# Altium schematic sheet iTimeAfrica.SchDoc(iTimeAfrica)
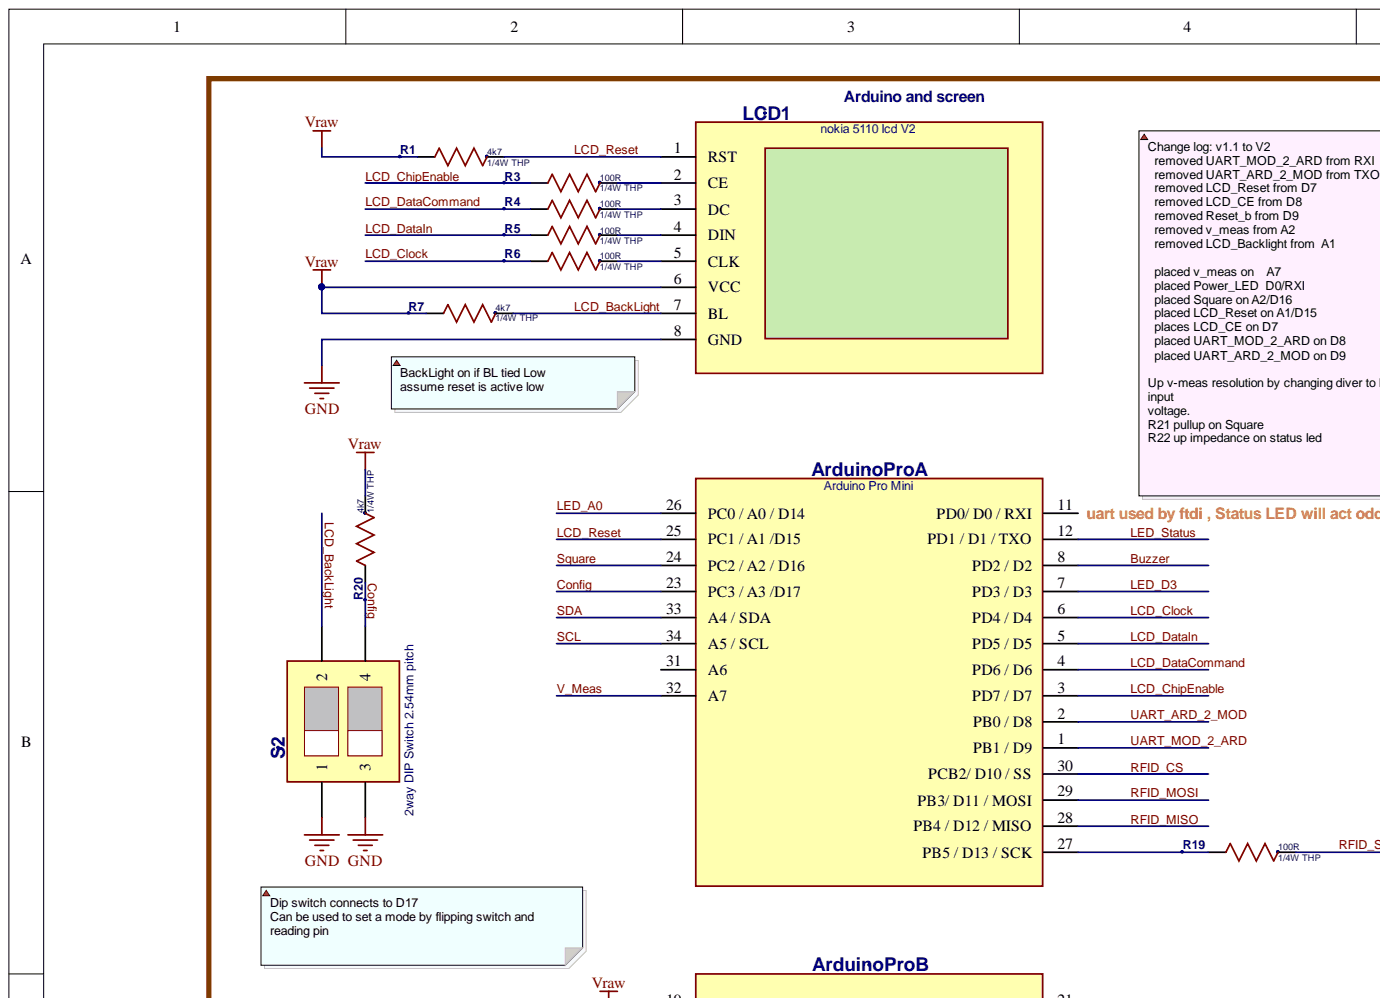
[diagram p0: iTimeAfrica.SchDoc, top left]
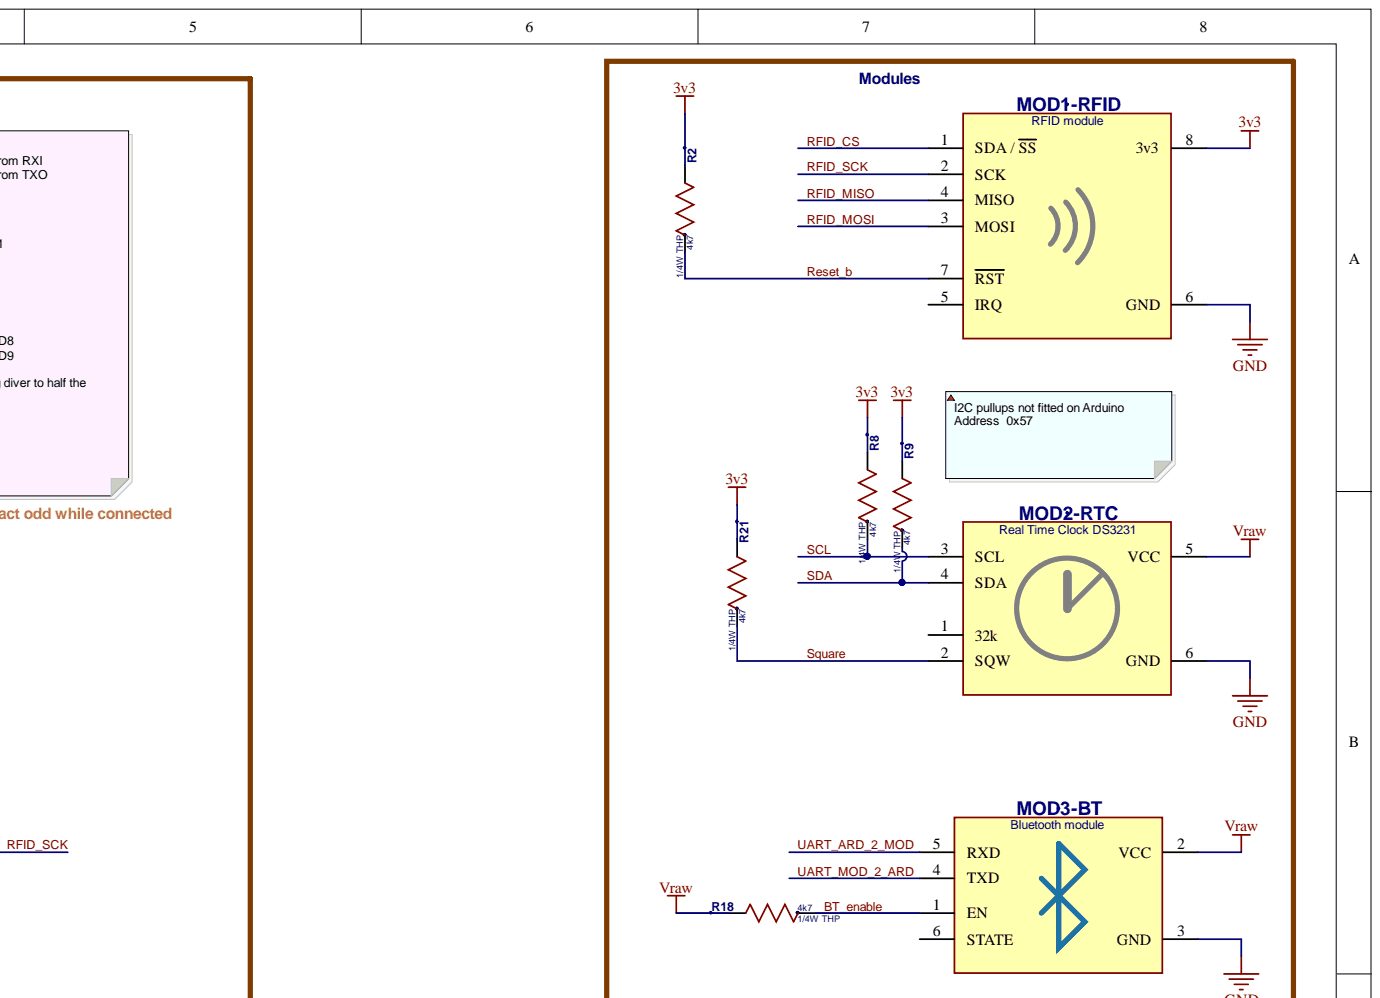
[diagram p1: iTimeAfrica.SchDoc, top right]
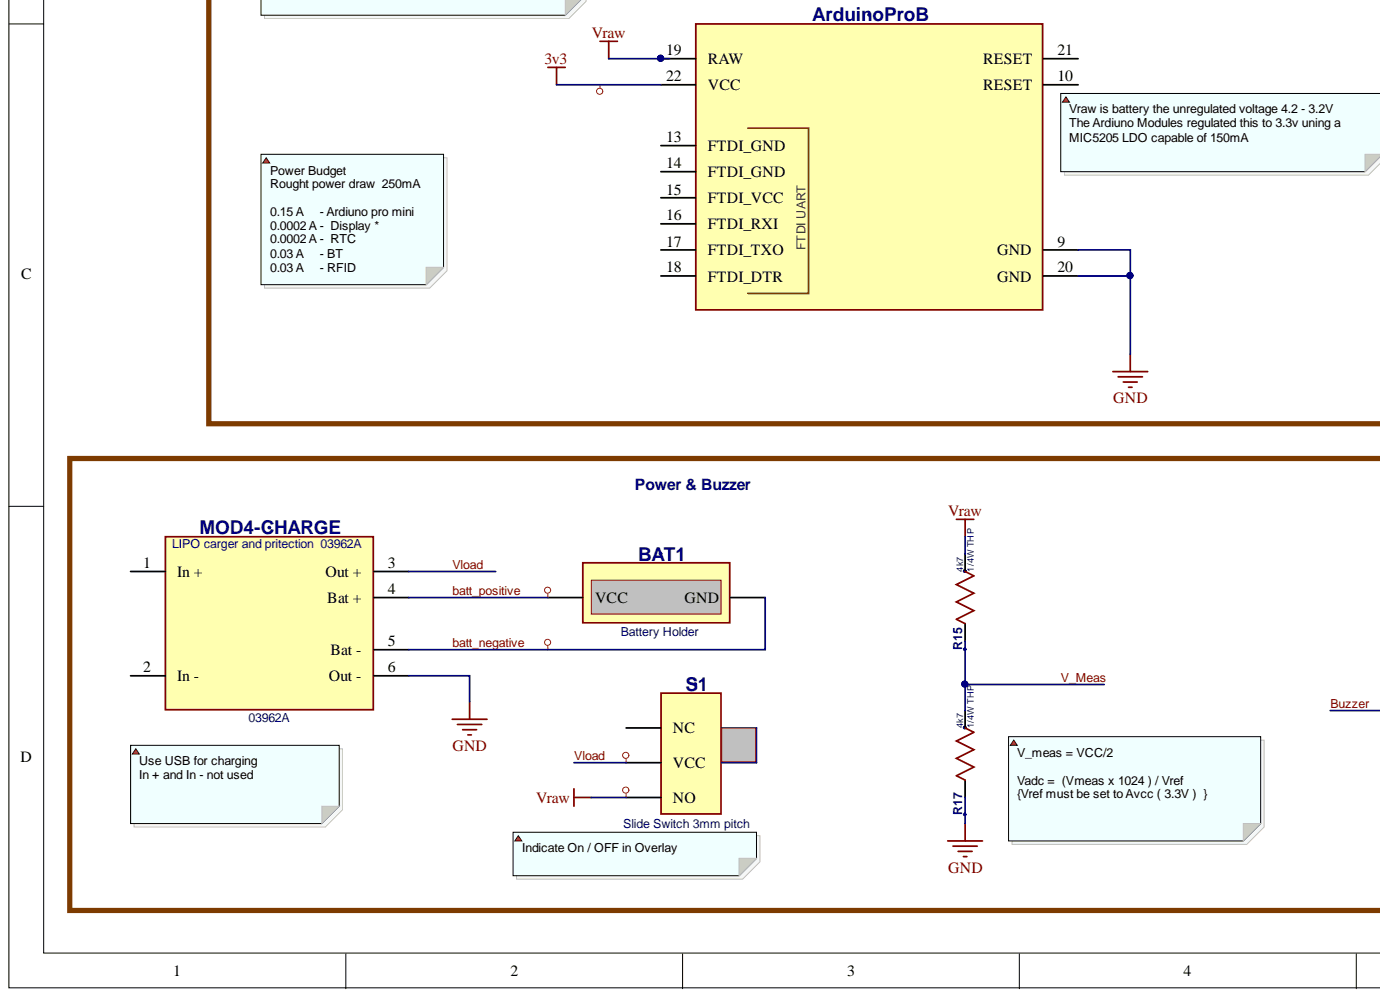
[diagram p2: iTimeAfrica.SchDoc, bottom left]
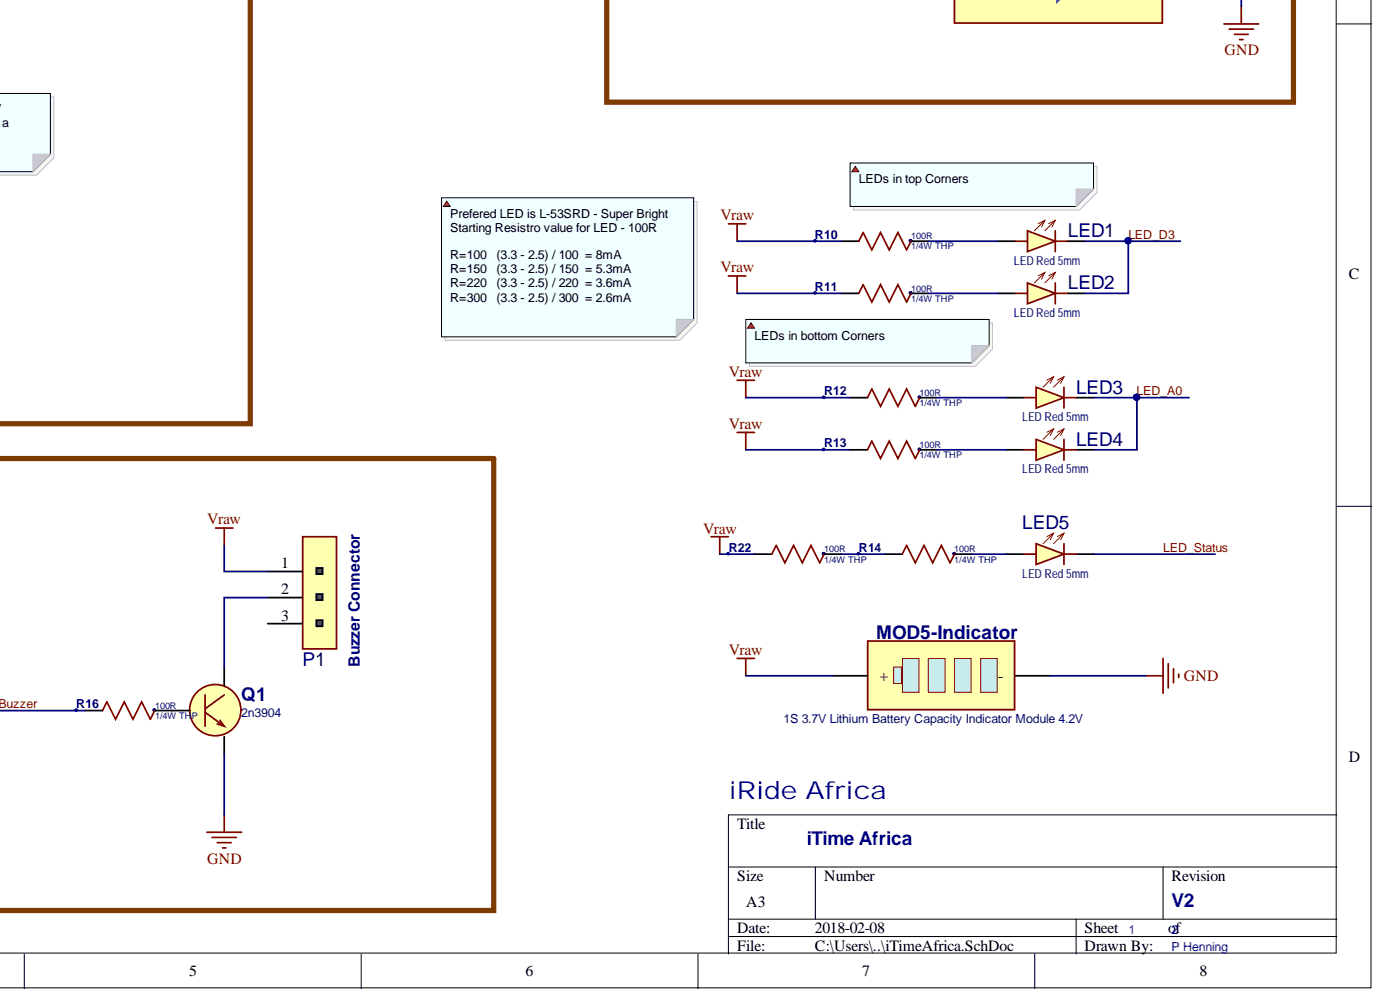
[diagram p3: iTimeAfrica.SchDoc, bottom right]

## Parts
ArduinoPro  Arduino Pro Mini  [ARDUINO PRO MINI v2 (NO FTDI)]  (parts A,B)
BAT1  Battery Holder  [Battery Holder]
LCD1  nokia 5110 lcd  [Nokia 5110 GLCD 84x48]
LED1  LED Red 5mm  [LED THL 5x9mm Vert]
LED2  LED Red 5mm  [LED THL 5x9mm Vert]
LED3  LED Red 5mm  [LED THL 5x9mm Vert]
LED4  LED Red 5mm  [LED THL 5x9mm Vert]
LED5  LED Red 5mm  [LED THL 5x9mm Vert]
MOD1-RFID  RFID module  [RFID-RC522 (2 MH)]
MOD2-RTC  Real Time Clock DS3231  [RTC DS3231 (2 MH)]
MOD3-BT  Bluetooth module  [BlueTooth Card]
MOD4-CHARGE  Real Time Clock DS3231  ManufacturerPartNumber: 03962A  [Charger/protection]
MOD5-Indicator  1S 3.7V Lithium Battery Capacity Indicator Module 4.2V  [Battery Indicator  single mouning hole]
P1  3 pin Screw Terminal 3.5mm  [3x1 Screw terminal 3.5mm]  (value not drawn)
Q1  2n3904  [TO-92]
R1  4k7  Package: 1/4W THP  [Res 1/4W THP (4k7)]
R2  4k7  Package: 1/4W THP  [Res 1/4W THP (4k7)]
R3  100R  Package: 1/4W THP  [Res 1/4W THP (100R)]
R4  100R  Package: 1/4W THP  [Res 1/4W THP (100R)]
R5  100R  Package: 1/4W THP  [Res 1/4W THP (100R)]
R6  100R  Package: 1/4W THP  [Res 1/4W THP (100R)]
R7  4k7  Package: 1/4W THP  [Res 1/4W THP (4k7)]
R8  4k7  Package: 1/4W THP  [Res 1/4W THP (4k7)]
R9  4k7  Package: 1/4W THP  [Res 1/4W THP (4k7)]
R10  100R  Package: 1/4W THP  [Res 1/4W THP (100R)]
R11  100R  Package: 1/4W THP  [Res 1/4W THP (100R)]
R12  100R  Package: 1/4W THP  [Res 1/4W THP (100R)]
R13  100R  Package: 1/4W THP  [Res 1/4W THP (100R)]
R14  100R  Package: 1/4W THP  [Res 1/4W THP (100R)]
R15  4k7  Package: 1/4W THP  [Res 1/4W THP (4k7)]
R16  100R  Package: 1/4W THP  [Res 1/4W THP (100R)]
R17  4k7  Package: 1/4W THP  [Res 1/4W THP (4k7)]
R18  4k7  Package: 1/4W THP  [Res 1/4W THP (4k7)]
R19  100R  Package: 1/4W THP  [Res 1/4W THP (100R)]
R20  4k7  Package: 1/4W THP  [Res 1/4W THP (4k7)]
R21  4k7  Package: 1/4W THP  [Res 1/4W THP (4k7)]
R22  100R  Package: 1/4W THP  [Res 1/4W THP (100R)]
S1  Slide Switch 3mm pitch  [Slide Switch 3mm]
S2  2x DIP Switch 2.54mm pitch  [2way DIP switch]  (value not drawn)

## Nets
3v3: ArduinoPro.22(VCC) MOD1-RFID.8(3v3) R2.1 R8.1 R9.1 R21.1
GND: ArduinoPro.9(GND) ArduinoPro.20(GND) LCD1.8(GND) MOD1-RFID.6(GND) MOD2-RTC.6(GND) MOD3-BT.3(GND) MOD4-CHARGE.6(Out_-) MOD5-Indicator.2 Q1.3 R17.1 S2.1 S2.3
Vraw: ArduinoPro.19(RAW) LCD1.6(VCC) MOD2-RTC.5(VCC) MOD3-BT.2(VCC) MOD5-Indicator.1 P1.1 R1.1 R7.1 R10.1 R11.1 R12.1 R13.1 R15.2 R18.1 R20.2 R22.1 S1.3
batt_negative: BAT1.2 MOD4-CHARGE.5(Bat_-)
batt_positive: BAT1.1 MOD4-CHARGE.4(Bat_+)
BT_enable: MOD3-BT.1(EN) R18.2
Buzzer: ArduinoPro.8(PD2_/_D2) R16.1
Config: ArduinoPro.23(PC3_/_A3_/D17) R20.1 S2.4
LCD_BackLight: LCD1.7(BL) R7.2 S2.2
LCD_ChipEnable: ArduinoPro.3(PD7_/_D7) R3.1
LCD_Clock: ArduinoPro.6(PD4_/_D4) R6.1
LCD_DataCommand: ArduinoPro.4(PD6_/_D6) R4.1
LCD_DataIn: ArduinoPro.5(PD5_/_D5) R5.1
LCD_Reset: ArduinoPro.25(PC1_/_A1_/D15) LCD1.1(RST) R1.2
LED_A0: ArduinoPro.26(PC0_/_A0_/_D14) LED3.2 LED4.2
LED_D3: ArduinoPro.7(PD3_/_D3) LED1.2 LED2.2
LED_Status: ArduinoPro.12(PD1_/_D1_/_TXO) LED5.2
Reset_b: MOD1-RFID.7(RST) R2.2
RFID_CS: ArduinoPro.30(PCB2/_D10_/_SS) MOD1-RFID.1(SDA_/_SS)
RFID_MISO: ArduinoPro.28(PB4_/_D12_/_MISO) MOD1-RFID.4(MISO)
RFID_MOSI: ArduinoPro.29(PB3/_D11_/_MOSI) MOD1-RFID.3(MOSI)
RFID_SCK: MOD1-RFID.2(SCK) R19.2
SCL: ArduinoPro.34(A5_/_SCL) MOD2-RTC.3(SCL) R8.2
SDA: ArduinoPro.33(A4_/_SDA) MOD2-RTC.4(SDA) R9.2
Square: ArduinoPro.24(PC2_/_A2_/_D16) MOD2-RTC.2(SQW) R21.2
UART_ARD_2_MOD: ArduinoPro.2(PB0_/_D8) MOD3-BT.5(RXD)
UART_MOD_2_ARD: ArduinoPro.1(PB1_/_D9) MOD3-BT.4(TXD)
V_Meas: ArduinoPro.32(A7) R15.1 R17.2
Vload: MOD4-CHARGE.3(Out_+) S1.2
NetArduinoPro_27: ArduinoPro.27(PB5_/_D13_/_SCK) R19.1
NetLCD1_2: LCD1.2(CE) R3.2
NetLCD1_3: LCD1.3(DC) R4.2
NetLCD1_4: LCD1.4(DIN) R5.2
NetLCD1_5: LCD1.5(CLK) R6.2
NetLED1_1: LED1.1 R10.2
NetLED2_1: LED2.1 R11.2
NetLED3_1: LED3.1 R12.2
NetLED4_1: LED4.1 R13.2
NetLED5_1: LED5.1 R14.2
NetP1_2: P1.2 Q1.1
NetQ1_2: Q1.2 R16.2
NetR14_1: R14.1 R22.2

Not connected: ArduinoPro 10(RESET) 11(PD0/_D0_/_RXI) 13(FTDI_GND) 14(FTDI_GND) 15(FTDI_VCC) 16(FTDI_RXI) 17(FTDI_TXO) 18(FTDI_DTR) 21(RESET) 31(A6); MOD1-RFID 5(IRQ); MOD2-RTC 1(32k); MOD3-BT 6(STATE); MOD4-CHARGE 1(In_+) 2(In_-); P1 3; S1 1

## Library data of the parts
ArduinoPro = Arduino Pro Mini: ManufacturerPartNumber: DEV-11113  (check this); Manufacturer: SparkFun Electronics; Supplier_1: https://www.aliexpress.com/item/2PCS-New-Pro-Mini-Atmega328-3-3V-8M-Replace-ATmega128-For-Arduino-Compatible-Nano/2037934450.html?spm=a2g0s.9042311.0.0.wP2Mco; Description: Arduino Pro Mini; Component Kind: Standard; Attributes: Arduino Pro Mini; Library Name: Copy of Undero_Symbols_v7.SchLib
LCD1 = nokia 5110 lcd V2: ManufacturerPartNumber: unknown; Supplier_1: https://www.aliexpress.com/item/84-48-LCD-Module-White-backlight-adapter-pcb-for-Nokia-5110/1859113549.html?spm=a2g0s.9042311.0.0.fpwn1h; Manufacturer: unknown; Description: nokia 5110 lcd V2; Component Kind: Standard; Attributes: nokia 5110 lcd; Library Name: Copy of Undero_Symbols_v7.SchLib
LED1-LED5 = Red LED THP: Red LED, 5mm THP; ManufacturerPartNumber: L-53SR; Manufacturer: kingbright; Supplier_1: http://www.communica.co.za/Catalog/Details/P2732841061; Package: 5mm x9; Component Kind: Standard; Attributes: LED Red 5mm; Library Name: Copy of Undero_Symbols_v7.SchLib
MOD1-RFID = RFID module: ManufacturerPartNumber: RFID-RC533; Supplier_1: https://www.aliexpress.com/item/13-56MHz-RFID-MF-RC522-RC-522-Reader-Writer-Module-I2C-Interface-2-Pins-Sensor-Card/32785550279.html?spm=a2g0s.9042311.0.0.wP2Mco; Description: RFID module; Component Kind: Standard; Attributes: RFID module; Library Name: Copy of Undero_Symbols_v7.SchLib
MOD4-CHARGE = Charger / Protection: LIPO carger and pritection  03962A; Component Kind: Standard; Attributes: Real Time Clock DS3231; Library Name: iTimeAfrica_Symbol.SchLib
MOD5-Indicator = Battery Indicator: Supplier_2: ?; Supplier_1: AlliExpress; Package: 45mm x 20mm; Description: 1S 3.7V Lithium Battery Capacity Indicator Module 4.2V; Component Kind: Standard; Attributes: 1S 3.7V Lithium Battery Capacity Indicator Module 4.2V; Library Name: Copy of Undero_Symbols_v7.SchLib
P1 = 3 pin Screw Terminal 3.5mm: ManufacturerPartNumber: 1984950; Manufacturer: RS; Supplier_1: https://www.netram.co.za/2955-screw-terminal-35mm-2-pin-5-pk.html; Package: 3x1 3.5mm; Description: 3 pin Screw Terminal 3.5mm; Component Kind: Standard; Attributes: 3 pin Screw Terminal 3.5mm; Library Name: Copy of Undero_Symbols_v7.SchLib
Q1 = NPN Transistor: Manufacturer: Fairchild; Supplier_1: http://www.communica.co.za/Catalog/Details/P0173638625; Package: TO92; ManufacturerPartNumber: 2n3904; Description: NPN Transistor; Component Kind: Standard; Attributes: 2n3904; Library Name: Copy of Undero_Symbols_v7.SchLib
R1 R2 R7-R9 R15 R17 R18 R20 R21 = 4k7: Resistor; Supplier_1: comunica; Component Kind: Standard; Attributes: 4k7; Library Name: iTimeAfrica_Symbol.SchLib
R3-R6 R10-R14 R16 R19 R22 = 100R: Resistor; Supplier_1: comunica; Component Kind: Standard; Attributes: 100R; Library Name: iTimeAfrica_Symbol.SchLib
S1 = Slide Switch 3mm pitch: Manufacturer: Arcolectric Corporation; Supplier_2: http://www.communica.co.za/catalog/Details/P2624616678; Supplier_1: http://www.communica.co.za/Catalog/Details/P2077750286; Package: 3x1 3mm; Description: Slide Switch 3mm pitch; Component Kind: Standard; Attributes: Slide Switch 3mm pitch; Library Name: Copy of Undero_Symbols_v7.SchLib
S2 = 2way DIP Switch 2.54mm pitch: ManufacturerPartNumber: KTS02E; Manufacturer: Arcolectric Corporation; Supplier_2: https://za.rs-online.com/web/p/dip-sip-switches/8772116/; Supplier_1: http://www.communica.co.za/Catalog/Details/P1597622190; Package: 2x2 2.54; Description: 2way DIP Switch 2.54mm pitch; Component Kind: Standard; Attributes: 2x DIP Switch 2.54mm pitch; Library Name: Copy of Undero_Symbols_v7.SchLib
BAT1 = Battery Holder: Supplier_2: ?; Supplier_1: AlliExpress; Description: Battery Holder; Component Kind: Standard; Attributes: Battery Holder; Library Name: Copy of Undero_Symbols_v7.SchLib
MOD2-RTC = Real Time Clock DS3231: Supplier_1: https://www.aliexpress.com/item/DS3231-AT24C32-IIC-High-Precision-RTC-Module-Clock-Timer-Memory-Module/2037934408.html?spm=a2g0s.9042311.0.0.wP2Mco; Description: Real Time Clock DS3231; Component Kind: Standard; Attributes: Real Time Clock DS3231; Library Name: Copy of Undero_Symbols_v7.SchLib
MOD3-BT = Bluetooth module: Supplier_1: https://www.aliexpress.com/item/Wireless-Serial-6-Pin-Bluetooth-RF-Transceiver-Module-HC-05-RS232-Master-Slave/2038549888.html?spm=a2g0s.9042311.0.0.wP2Mco; Description: Bluetooth module; Component Kind: Standard; Attributes: Bluetooth module; Library Name: Copy of Undero_Symbols_v7.SchLib
